# Altium schematic sheet tracker_main.SchDoc(tracker_main)
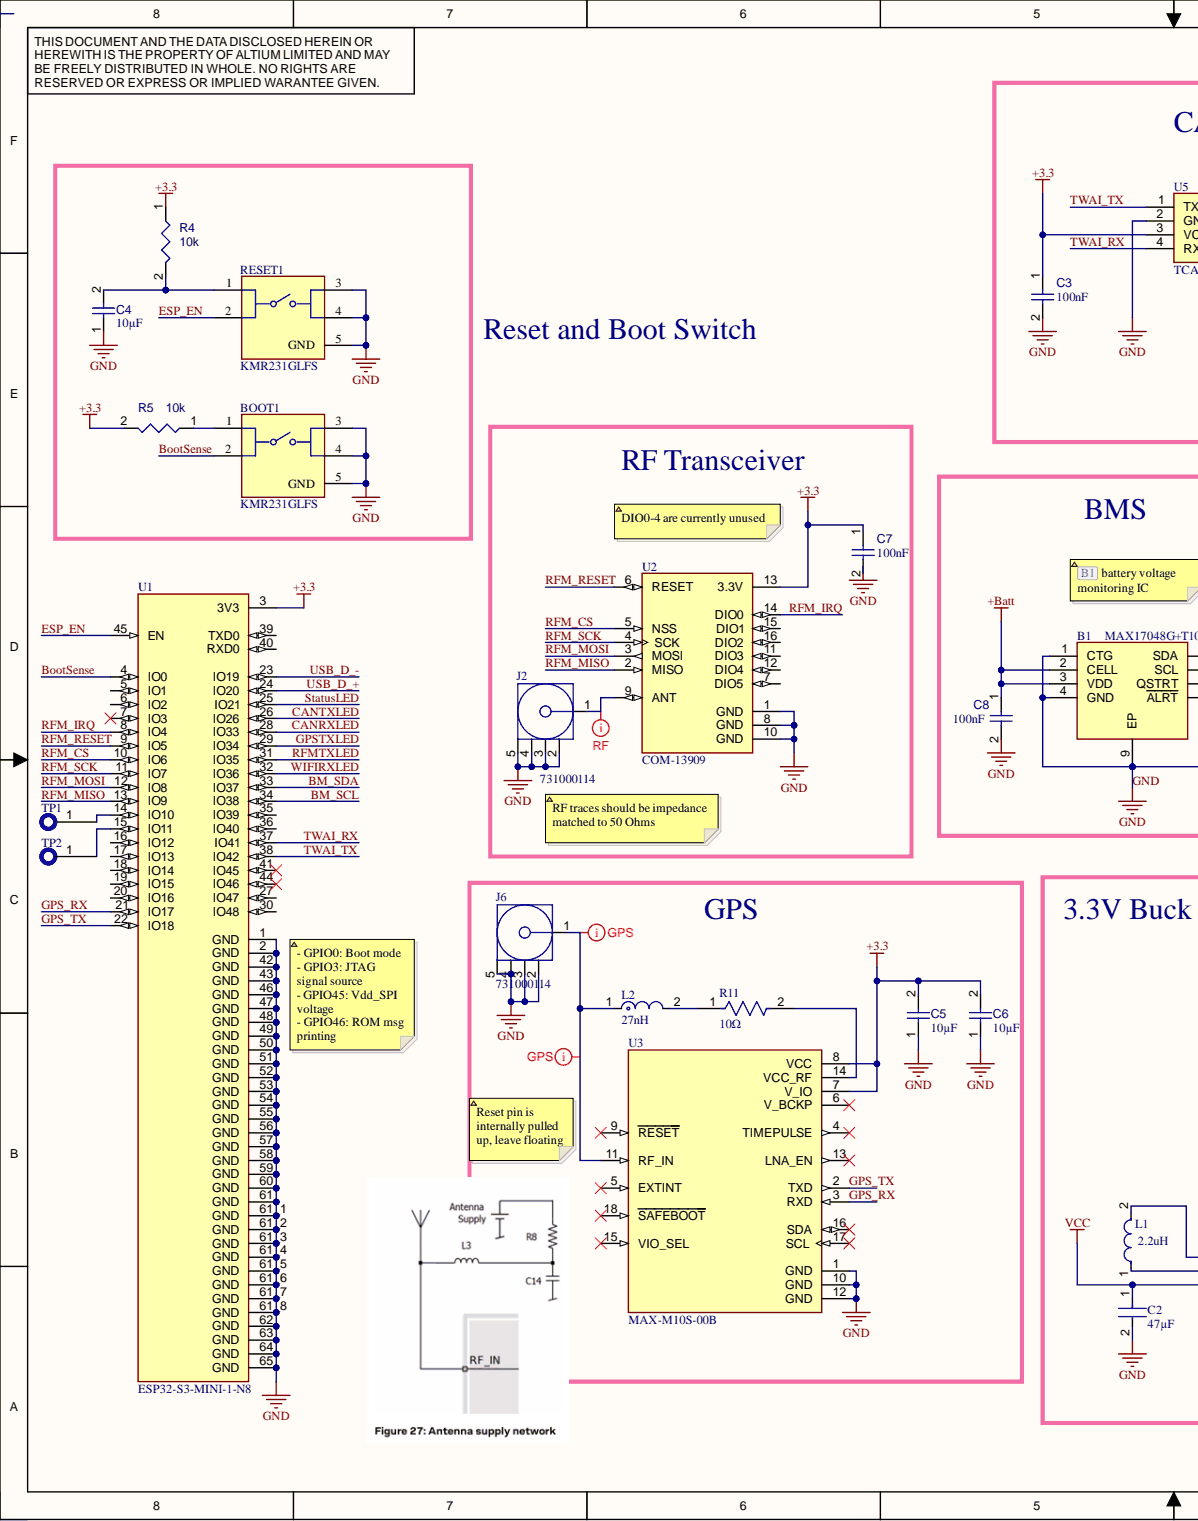
[diagram p0: tracker_main.SchDoc, left]
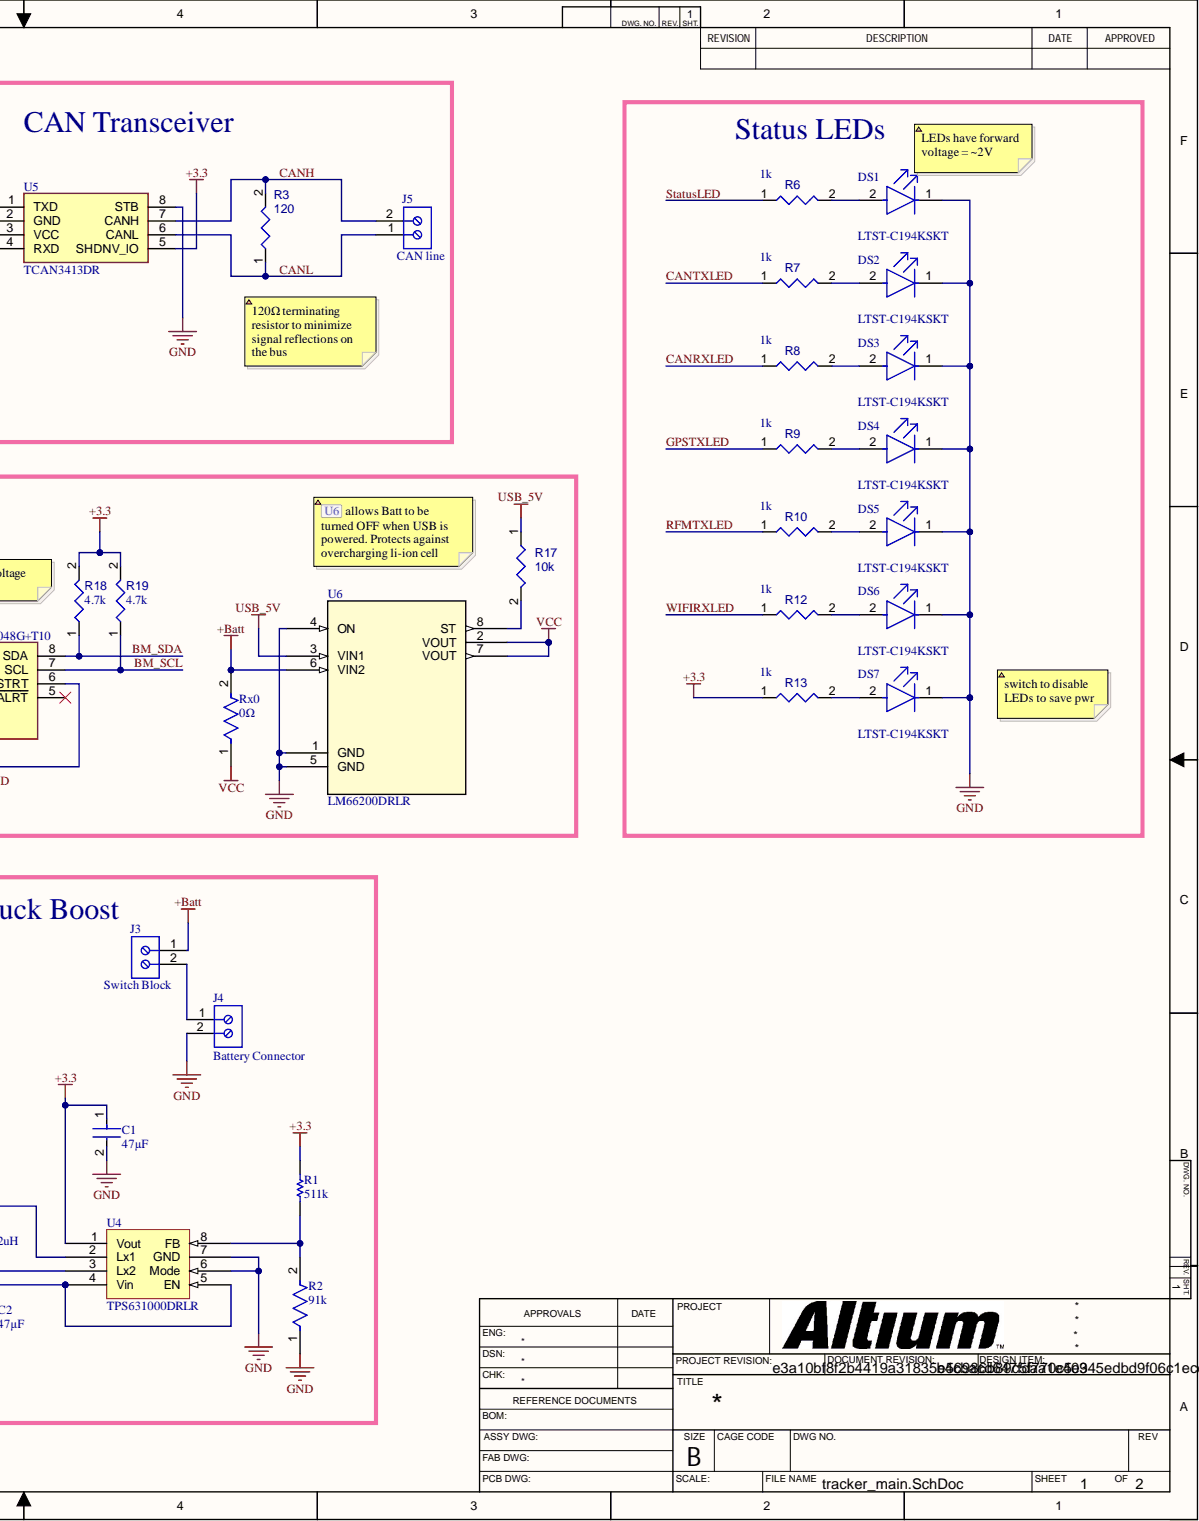
[diagram p1: tracker_main.SchDoc, right]

## Parts
B1  MAX17048G+T10  [SON50P200X200X80-9N-D]
BOOT1  KMR231GLFS  [FP-KMR231GLFS-MFG]
C1  47µF  [FP-0603-L_1_6_0_2-W_0_8_0-MFG]
C2  47µF  [FP-0603-L_1_6_0_2-W_0_8_0-MFG]
C3  100nF  [CAPC1608X90X40NL10T20]
C4  value 10µF  [CAPC0603(1608)100_L]  (hidden comment: Capacitor 10µF +/-20% 10V 0603)
C5  value 10µF  [CAPC0603(1608)100_L]  (hidden comment: Capacitor 10µF +/-20% 10V 0603)
C6  value 10µF  [CAPC0603(1608)100_L]  (hidden comment: Capacitor 10µF +/-20% 10V 0603)
C7  100nF  [CAPC1608X90X40NL10T20]
C8  100nF  [CAPC1608X90X40NL10T20]
DS1  LTST-C194KSKT  [FP-LTST-C194KSKT-MFG]
DS2  LTST-C194KSKT  [FP-LTST-C194KSKT-MFG]
DS3  LTST-C194KSKT  [FP-LTST-C194KSKT-MFG]
DS4  LTST-C194KSKT  [FP-LTST-C194KSKT-MFG]
DS5  LTST-C194KSKT  [FP-LTST-C194KSKT-MFG]
DS6  LTST-C194KSKT  [FP-LTST-C194KSKT-MFG]
DS7  LTST-C194KSKT  [FP-LTST-C194KSKT-MFG]
J2  731000114  [MOLX-731000114_V]
J3  Switch Block  [PHOE-1725656-2]
J4  Battery Connector  [PHOE-1725656-2]
J5  CAN line  [PHOE-1725656-2]
J6  731000114  [MOLX-731000114_V]
L1  value 2.2uH  [FP-DFE21CC_EL-MFG]  (hidden comment: DFE21CCN2R2MELL)
L2  27nH  [FP-LQG18HH_00-IPC_C]
R1  511k  [RESC1609X50X30NL10T20]
R2  91k  [FP-CRCW0603-HPe3-IPC_B]
R3  120  [RESC0603(1608)_L]
R4  10k  [RESC1608X55X25ML10T15]
R5  10k  [RESC1608X55X25ML10T15]
R6  value 1k  [RESC1608X55X25ML10T15]  (hidden comment: Resistor 1k +/-1% 0603 100 mW)
R7  value 1k  [RESC1608X55X25ML10T15]  (hidden comment: Resistor 1k +/-1% 0603 100 mW)
R8  value 1k  [RESC1608X55X25ML10T15]  (hidden comment: Resistor 1k +/-1% 0603 100 mW)
R9  value 1k  [RESC1608X55X25ML10T15]  (hidden comment: Resistor 1k +/-1% 0603 100 mW)
R10  value 1k  [RESC1608X55X25ML10T15]  (hidden comment: Resistor 1k +/-1% 0603 100 mW)
R11  10Ω  [FP-RNCF0603-MFG]
R12  value 1k  [RESC1608X55X25ML10T15]  (hidden comment: Resistor 1k +/-1% 0603 100 mW)
R13  value 1k  [RESC1608X55X25ML10T15]  (hidden comment: Resistor 1k +/-1% 0603 100 mW)
R17  10k  [RESC1608X55X25ML10T15]
R18  value 4.7k  [RESC1608X55X25ML10T15]  (hidden comment: Resistor 4k7 +/-1% 0603 100 mW)
R19  value 4.7k  [RESC1608X55X25ML10T15]  (hidden comment: Resistor 4k7 +/-1% 0603 100 mW)
RESET1  KMR231GLFS  [FP-KMR231GLFS-MFG]
Rx0  0Ω  [FP-HCJ0805-MFG]
TP1  TP_1  [Testpoint1]  (no BOM)
TP2  TP_1  [Testpoint1]  (value not drawn)  (no BOM)
U1  ESP32-S3-MINI-1-N8  [XCVR_ESP32-S3-MINI-1-N8]
U2  COM-13909  [MOD_COM-13909]
U3  MAX-M10S-00B  [XCVR_MAX-M10S-00B]
U4  TPS631000DRLR  [SON50P120X60-8N]
U5  TCAN3413DR  [SOIC127P600X175-8N]
U6  LM66200DRLR  [SOT-5X3_DRL_TEX]

## Nets
+3.3: C1.1 C3.1 C5.2 C6.2 C7.1 R1.2 R4.1 R5.2 R13.1 R18.2 R19.2 U1.3(3V3) U2.13(3.3V) U3.7(V_IO) U3.8(VCC) U4.1(Vout) U5.3(VCC) U5.5(SHDNV_IO)
+Batt: B1.2(CELL) B1.3(VDD) C8.1 J3.1 Rx0.2 U6.6(VIN2)
USB_5V: R17.1 U6.3(VIN1)
VCC: C2.1 Rx0.1 U4.4(Vin) U4.5(EN) U6.2(VOUT) U6.7(VOUT)
BM_SCL: B1.7(SCL) R19.1 U1.34(IO38)
BM_SDA: B1.8(SDA) R18.1 U1.33(IO37)
BootSense: BOOT1.2 U1.4(IO0)
CANH: J5.2 R3.2 U5.7(CANH)
CANL: J5.1 R3.1 U5.6(CANL)
CANRXLED: R8.1 U1.28(IO33)
CANTXLED: R7.1 U1.26(IO26)
ESP_EN: RESET1.2 U1.45(EN)
GND: B1.1(CTG) B1.4(GND) B1.6(QSTRT) B1.9(EP) BOOT1.3 BOOT1.4 BOOT1.5(GND) C1.2 C2.2 C3.2 C4.1 C5.1 C6.1 C7.2 C8.2 DS1.1 DS2.1 DS3.1 DS4.1 DS5.1 DS6.1 DS7.1 J2.2 J2.3 J2.4 J2.5 J4.2 J6.2 J6.3 J6.4 J6.5 R2.1 RESET1.3 RESET1.4 RESET1.5(GND) U1.1(GND) U1.2(GND) U1.42(GND) U1.43(GND) U1.46(GND) U1.47(GND) U1.48(GND) U1.49(GND) U1.50(GND) U1.51(GND) U1.52(GND) U1.53(GND) U1.54(GND) U1.55(GND) U1.56(GND) U1.57(GND) U1.58(GND) U1.59(GND) U1.60(GND) U1.61(GND) U1.61_1(GND) U1.61_2(GND) U1.61_3(GND) U1.61_4(GND) U1.61_5(GND) U1.61_6(GND) U1.61_7(GND) U1.61_8(GND) U1.62(GND) U1.63(GND) U1.64(GND) U1.65(GND) U2.1(GND) U2.8(GND) U2.10(GND) U3.1(GND) U3.10(GND) U3.12(GND) U4.6(Mode) U4.7(GND) U5.2(GND) U5.8(STB) U6.1(GND) U6.4(ON) U6.5(GND)
GPS_RX: U1.21(IO17) U3.3(RXD)
GPS_TX: U1.22(IO18) U3.2(TXD)
GPSTXLED: R9.1 U1.29(IO34)
RFM_CS: U1.10(IO6) U2.5(NSS)
RFM_IRQ: U1.8(IO4) U2.14(DIO0)
RFM_MISO: U1.13(IO9) U2.2(MISO)
RFM_MOSI: U1.12(IO8) U2.3(MOSI)
RFM_RESET: U1.9(IO5) U2.6(RESET)
RFM_SCK: U1.11(IO7) U2.4(SCK)
RFMTXLED: R10.1 U1.31(IO35)
StatusLED: R6.1 U1.25(IO21)
TWAI_RX: U1.37(IO41) U5.4(RXD)
TWAI_TX: U1.38(IO42) U5.1(TXD)
USB_D_+: U1.24(IO20)
USB_D_-: U1.23(IO19)
WIFIRXLED: R12.1 U1.32(IO36)
NetBOOT1_1: BOOT1.1 R5.1
NetC4_2: C4.2 R4.2 RESET1.1
NetDS1_2: DS1.2 R6.2
NetDS2_2: DS2.2 R7.2
NetDS3_2: DS3.2 R8.2
NetDS4_2: DS4.2 R9.2
NetDS5_2: DS5.2 R10.2
NetDS6_2: DS6.2 R12.2
NetDS7_2: DS7.2 R13.2
NetJ2_1: J2.1 U2.9(ANT)
NetJ3_2: J3.2 J4.1
NetJ6_1: J6.1 L2.1 U3.11(RF_IN)
NetL1_1: L1.1 U4.3(Lx2)
NetL1_2: L1.2 U4.2(Lx1)
NetL2_2: L2.2 R11.1
NetR1_1: R1.1 R2.2 U4.8(FB)
NetR11_2: R11.2 U3.14(VCC_RF)
NetR17_2: R17.2 U6.8(ST)
NetTP1_1: TP1.1 U1.14(IO10)
NetTP2_1: TP2.1 U1.15(IO11)

Not connected: B1 5(ALRT); U1 5(IO1) 6(IO2) 7(IO3) 16(IO12) 17(IO13) 18(IO14) 19(IO15) 20(IO16) 27(IO47) 30(IO48) 35(IO39) 36(IO40) 39(TXD0) 40(RXD0) 41(IO45) 44(IO46); U2 7(DIO5) 11(DIO3) 12(DIO4) 15(DIO1) 16(DIO2); U3 4(TIMEPULSE) 5(EXTINT) 6(V_BCKP) 9(RESET) 13(LNA_EN) 15(VIO_SEL) 16(SDA) 17(SCL) 18(SAFEBOOT)

## Library data of the parts
B1 = CMP-013-000000-1: 3A 1-Cell/2-Cell Fuel Gauge with ModelGauge; Manufacturer_Name: Analog Devices; Geometry.Height: 0.8mm; Arrow Part Number: MAX17048G+T10; Arrow Price/Stock: https://www.arrow.com/en/products/max17048gt10/analog-devices?utm_currency=USD&region=nac; Component Kind: Standard; Datasheet Link: https://www.analog.com/MAX17048/datasheet; Design Item ID: MAX17048G+T10; Manufacturer_Part_Number: MAX17048G+T10; Mouser Part Number: 700-MAX17048G+T10; Mouser Price/Stock: https://www.mouser.co.uk/ProductDetail/Analog-Devices-Maxim-Integrated/MAX17048G%2bT10?qs=D7PJwyCwLAoGnnn8jEPRBQ%3D%3D; Source: MAX17048G+T10.SchLib; Library Name: UTD Workspace
BOOT1 RESET1 = CMP-2000-06516-3: SWITCH TACTILE SPST-NO 0.05A 32V; Actuator Length: 0.5mm; Actuator Type: Standard; Contact Current Rating: 50mA; Contact Resistance: 100mR; Illumination Type: Non-Illuminated; Insulation Resistance: 1GR; Mechanical Life: 150000Cycles; Operating Force: 3N; Power Rating: 1W; Switch Function: Off-Mom; Switch Travel: 0.25mm; Throw Configuration: SPST; Case/Package: SMD/SMT; Component Kind: Standard; Contact Material: Silver; Contact Plating: Silver; Current Rating: 50mA; Depth: 4.6mm; Lead Free: Lead Free; Length: 4.6mm; Library Name: Altium Content Vault; Max Current Rating: 50mA; Max Operating Temperature: 85°C; Max Voltage Rating (DC): 32V; Min Operating Temperature: -40°C; Mount: Surface Mount; Orientation: Straight; Package Quantity: 7000; Packaging: Cut Tape; Radiation Hardening: No; REACH SVHC: No SVHC; Series: KMR2; Termination: Gull Wing; Voltage Rating (DC): 32V; Width: 2.8mm
C1 C2 = CMP-04427-000093-1: CAP CER 47UF 6.3V X5R 0603 06036D476MAT2A; Tolerance: 20%; Capacitance: 47uF; Case Code (Imperial): 0603; Case Code (Metric): 1608; Case/Package: 0603; Component Kind: Standard; Library Name: Altium Content Vault; Max Operating Temperature: 85°C; Min Operating Temperature: -55°C; Mount: Surface Mount; Packaging: Tape and Reel; RoHS Compliant: Yes; Voltage Rating: 6.3V
C3 C7 C8 = CMP-001-00017-6: Chip Capacitor, 100 nF, +/- 10%, 50 V, 0603 (1608 Metric); Capacitor Type: Ceramic; Voltage Rating: 50V; Case/Package: 0603; Component Kind: Standard; Max Operating Temperature: 125°C; Min Operating Temperature: -55°C; Mounting Technology: SM; Pins: 2; RoHS Compliant: True; Tolerance: 10%; Value: 100nF; Library Name: Aadesh Senthilkumar
C4-C6 = CMP-001-00075-4: Chip Capacitor, 10µF +/-20%, 10V, 0603, Thickness 1 mm; Tolerance: 10%; Case/Package: 0603; Component Kind: Standard; Library Name: The University of Texas at Dallas; Max Operating Temperature: 85°C; Min Operating Temperature: -55°C; Mounting Technology: SMT; Pins: 2; Voltage Rating: 10V
DS1-DS7 = CMP-007-00037-1: Single Color LED, Yellow, Water Clear, 1.15mm; Forward Current: 30mA; Forward Voltage: 2V; Case/Package: 0603; Component Kind: Standard; Max Operating Temperature: 85°C; Min Operating Temperature: -55°C; Mounting Technology: Surface Mount; Pins: 2; Library Name: UTD Workspace
J2 J6 = CMP-2000-05487-1: SMA Right-Angle PCB Jack Receptacle, Brass Body, Gold Plated, 50 Ohm, 5-Pin THD, RoHS, Tray; Manufacturer: Molex; Component Kind: Standard; Datasheet URL: http://www.molex.com/webdocs/datasheets/pdf/en-us/0731000114_RF_COAX_CONNECTORS.pdf; Datasheet Version: 09/2016; Library Name: Altium Content Vault; Manufacturer URL: http://www.molex.com/; Mounting Technology: ThroughHole; Package Description: 5-Pin Through Hole Device, Body 14.8 x 6.35 mm, Pitch 2.54 mm; Package Reference: 731000114; Package URL: http://www.molex.com/pdm_docs/sd/731000114_sd.pdf
J3-J5 = CMP-2000-05299-1: Male Header, Pitch 2.54 mm, 1 x 2 Position, Height 9 mm, Tail Length 3.5 mm; Manufacturer: Phoenix Contact; Manufacturer part No.: 1725656; Content: 250pc(s); Industrial packaging: Yes; Number of contacts: 2; Pitch: 2.54mm; Colour: Green; Component Kind: Standard; Datasheet URL: http://datasheet.octopart.com/1725656-Phoenix-Contact-datasheet-5302866.pdf; Datasheet Version: Apr-08; Library Name: Altium Content Vault; Manufacturer URL: http://www.phoenixcontact.com/; Type: MPT0,5/2-2,54
L1 = CMP-06042-017091-1: FIXED IND 2.2UH 2100MA NONAUTO; Component Kind: Standard; Library Name: Altium Content Vault
L2 = CMP-06042-012021-1: Multilayer type Inductor for Automotive 27nH ±5% 0.54Ω 500mA 0603 LQG18HH27NJ00D; DC Resistance (DCR): 4.18mR; Case/Package: 0603; Component Kind: Standard; Inductance: 27nH; Library Name: Altium Content Vault; Package Quantity: 4000; Packaging: Tape and Reel; Termination: SMD/SMT
R1 = CMP-2000-03441-1: CRCW0603511KFKEA Res Thick Film 0603 511K Ohm 1% 0.1W(1/10W) ±100ppm/C Pad SMD Automotive T/R; Manufacturer: Vishay Dale; Component Kind: Standard; Library Name: Altium Content Vault; Part Number: CRCW0603511KFKEA
R2 = CMP-02407-006578-1: CRCW060391K0FKEAHP RES Thick Film, 91kΩ, 1%, 0.33W, 100ppm/°C, 0603; Max Power Dissipation: 250mW; Resistance: 91kR; Case/Package: 0603; Component Kind: Standard; Composition: Thick Film; Contact Plating: Tin; Features: Automotive AEC-Q200; Height: 0.022inch; Lead Free: Lead Free; Length: 0.063inch; Library Name: Altium Content Vault; Max Operating Temperature: 155°C; Min Operating Temperature: -55°C; Mount: Surface Mount; Number of Terminations: 2; Package Quantity: 5000; Packaging: Tape and Reel; Power Rating: 250mW; Temperature Coefficient: 100ppm/°C; Termination: Wraparound; Tolerance: 1%; Width: 0.033inch
R3 = CMP-009-00176-3: Chip Resistor, 120 Ohms, +/-5 %, 0.1 W, -55 to 155 degC, 0603; Power: 100mW; Tolerance: 5%; Case/Package: 0603; Component Kind: Standard; Max Operating Temperature: 155°C; Min Operating Temperature: -55°C; Pins: 2; Value: 120; Library Name: Aadesh Senthilkumar
R4 R5 R17 = CMP-009-00017-5: Chip Resistor, 10 KOhm, +/- 1%, 01 W, -55 to 155 degC, 0603 (1608 Metric); Power: 100mW; Voltage Rating: 50V; Case/Package: 0603; Component Kind: Standard; Max Operating Temperature: 155°C; Min Operating Temperature: -55°C; Mounting Technology: SM; Pins: 2; RoHS Compliant: True; Tolerance: 1%; Value: 10k; Library Name: Aadesh Senthilkumar
R6-R10 R12 R13 = CMP-009-00014-5: Chip Resistor, 1 KOhm, +/-1%, 0.1 W, -55 to 155 degC, 0603 (1608 Metric); Power: 100mW; Tolerance: 1%; Voltage Rating: 50V; Case/Package: 0603; Component Kind: Standard; Max Operating Temperature: 155°C; Min Operating Temperature: -55°C; Mounting Technology: SM; Pins: 2; RoHS Compliant: True; Library Name: UTD Workspace
R11 = CMP-26527-002750-1: RES 10 OHM 0.05% 1/10W 0603 RNCF0603AKT10R0; Max Power Dissipation: 100mW; Resistance: 10R; Temperature Coefficient: 10ppm/°C; Case Code (Imperial): 0603; Case Code (Metric): 1608; Case/Package: 0603; Component Kind: Standard; Features: Moisture Resistant; Height: 0.022inch; Length: 0.063inch; Library Name: Altium Content Vault; Max Operating Temperature: 155°C; Min Operating Temperature: -55°C; Mount: Surface Mount; Number of Terminations: 2; Packaging: Tape and Reel; Resistor Type: Thin Film; RoHS Compliant: Yes; Tolerance: 0.05%; Width: 0.031inch
R18 R19 = CMP-009-00031-5: Chip Resistor, 4.7 KOhm, +/- 1%, 0.1 W, -55 to 155 degC, 0603 (1608 Metric); Power: 100mW; Case/Package: 0603; Component Kind: Standard; Max Operating Temperature: 155°C; Min Operating Temperature: -55°C; Mounting Technology: SM; Pins: 2; RoHS Compliant: True; Tolerance: 1%; Library Name: UTD Workspace
Rx0 = CMP-26527-000104-1: RES 0 OHM JUMPER 1/2W 0805; Resistance: 0mR; Case Code (Imperial): 0805; Case Code (Metric): 2012; Case/Package: 0805; Component Kind: Standard; Height: 0.026inch; Lead Free: Lead Free; Length: 0.079inch; Library Name: Altium Content Vault; Max Operating Temperature: 155°C; Min Operating Temperature: -55°C; Mount: Surface Mount; Number of Terminations: 2; Package Quantity: 1; Packaging: Tape and Reel; Radiation Hardening: No; RoHS Compliant: Yes; Termination: Wraparound; Width: 0.049inch
TP1 TP2 = Testpoint1: Library Name: Schlib1.SchLib
U1 = CMP-003-000000-2: SMD module, ESP32-S3FN8, 8 MB SPI flash, PCB antenna; MF: {'manufacturer': u'Espressif Systems'}; Availability: Unavailable; Component Kind: Standard; Component Type: Standard; Design Item ID: ESP32-S3-MINI-1-N8; MP: ESP32-S3-MINI-1-N8; Package: None; Pin Count: 73; Price: None; RoHS: Compliant; Source: ESP32-S3-MINI-1-N8.SchLib; Library Name: Aadesh Senthilkumar
U2 = CMP-00025-00003-3: Rfm69hcw Wireless Transceiver 91; Availability: Unavailable; Case/Package: None; Component Kind: Standard; Component Type: Standard; Design Item ID: COM-13909; MP: COM-13909; Pins: 16; Price: None; Source: COM-13909.SchLib; Library Name: UTD Workspace
U3 = CMP-007-000000-1: Gnss / Gps Modules U-Blox M10 Gnss Module Rom, Tcxo, Lna, Saw Lcc, 9.7X10 Mm, 500 Pcs/Reel; Availability: Unavailable; Component Kind: Standard; Component Type: Standard; Design Item ID: MAX-M10S-00B; MP: MAX-M10S-00B; Package: None; Pin Count: 18; Price: None; Source: MAX-M10S-00B.SchLib; Library Name: Aadesh Senthilkumar
U4 = CMP-019-00050-1: 1.5-A output current, high-power-density buck-boost converter 8-SOT-5X3 -40 to 125; Component Kind: Standard; Library Name: The University of Texas at Dallas
U5 = TCAN3413DR: Integrated Circuit; Manufacturer_Name: Texas Instruments; Arrow Part Number: TCAN3413DR; Arrow Price/Stock: https://www.arrow.com/en/products/tcan3413dr/texas-instruments?utm_currency=USD&region=nac; Component Kind: Standard; Datasheet Link: https://www.ti.com/lit/ds/symlink/tcan3413.pdf?ts=1704463166829&ref_url=https%253A%252F%252Fwww.ti.com%252Fproduct%252Fde-de%252FTCAN3413; Height: 1.75mm; Manufacturer_Part_Number: TCAN3413DR; Mouser Part Number: 595-TCAN3413DR; Mouser Price/Stock: https://www.mouser.co.uk/ProductDetail/Texas-Instruments/TCAN3413DR?qs=mELouGlnn3eIMT2B6sccyw%3D%3D
U6 = CMP-004-00031-1: 1.6-V to 5.5-V, 40-mâ„; Manufacturer_Name: Texas Instruments; Component Kind: Standard; Design Item ID: LM66200DRLR; Manufacturer_Part_Number: LM66200DRLR; RefDes: RefDes; Source: LM66200DRLR.SchLib; Type: Type; Copyright: Copyright (C) 2025 Ultra Librarian. All rights reserved.; Library Name: UTD Workspace
